# Altium schematic sheet C3 TransmissionBoardSchDoc.SchDoc
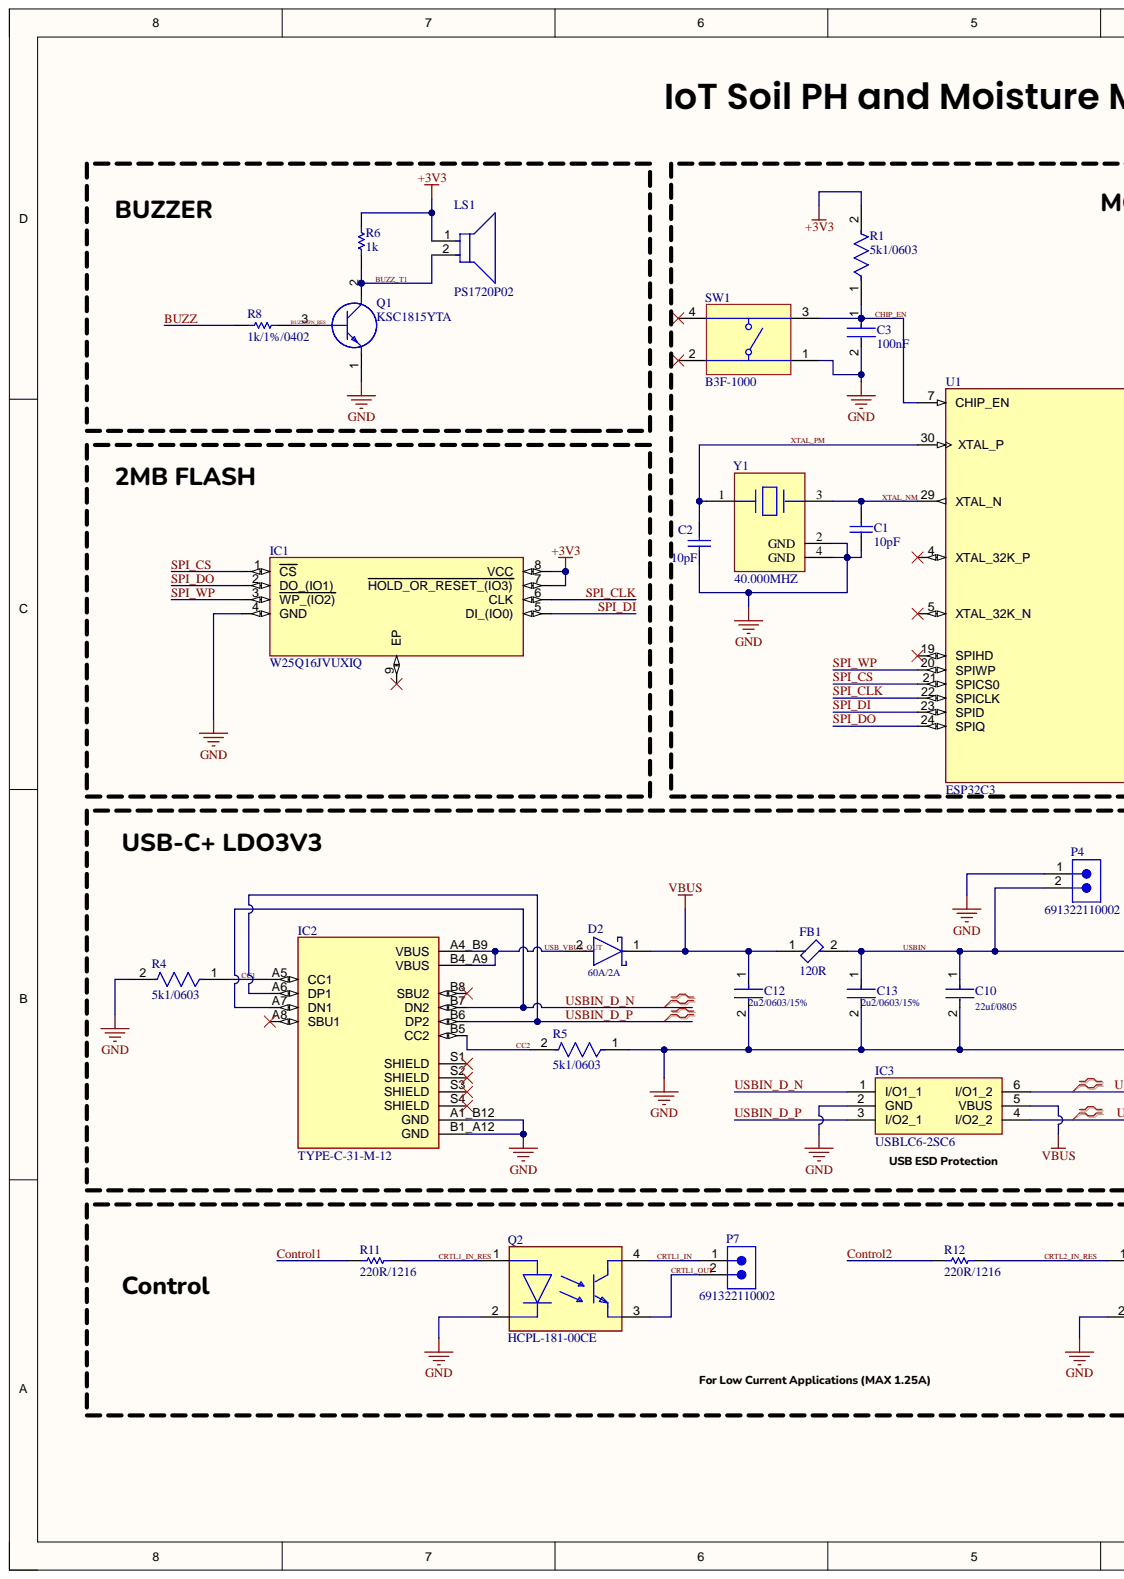
[diagram p0: C3 TransmissionBoardSchDoc.SchDoc, left]
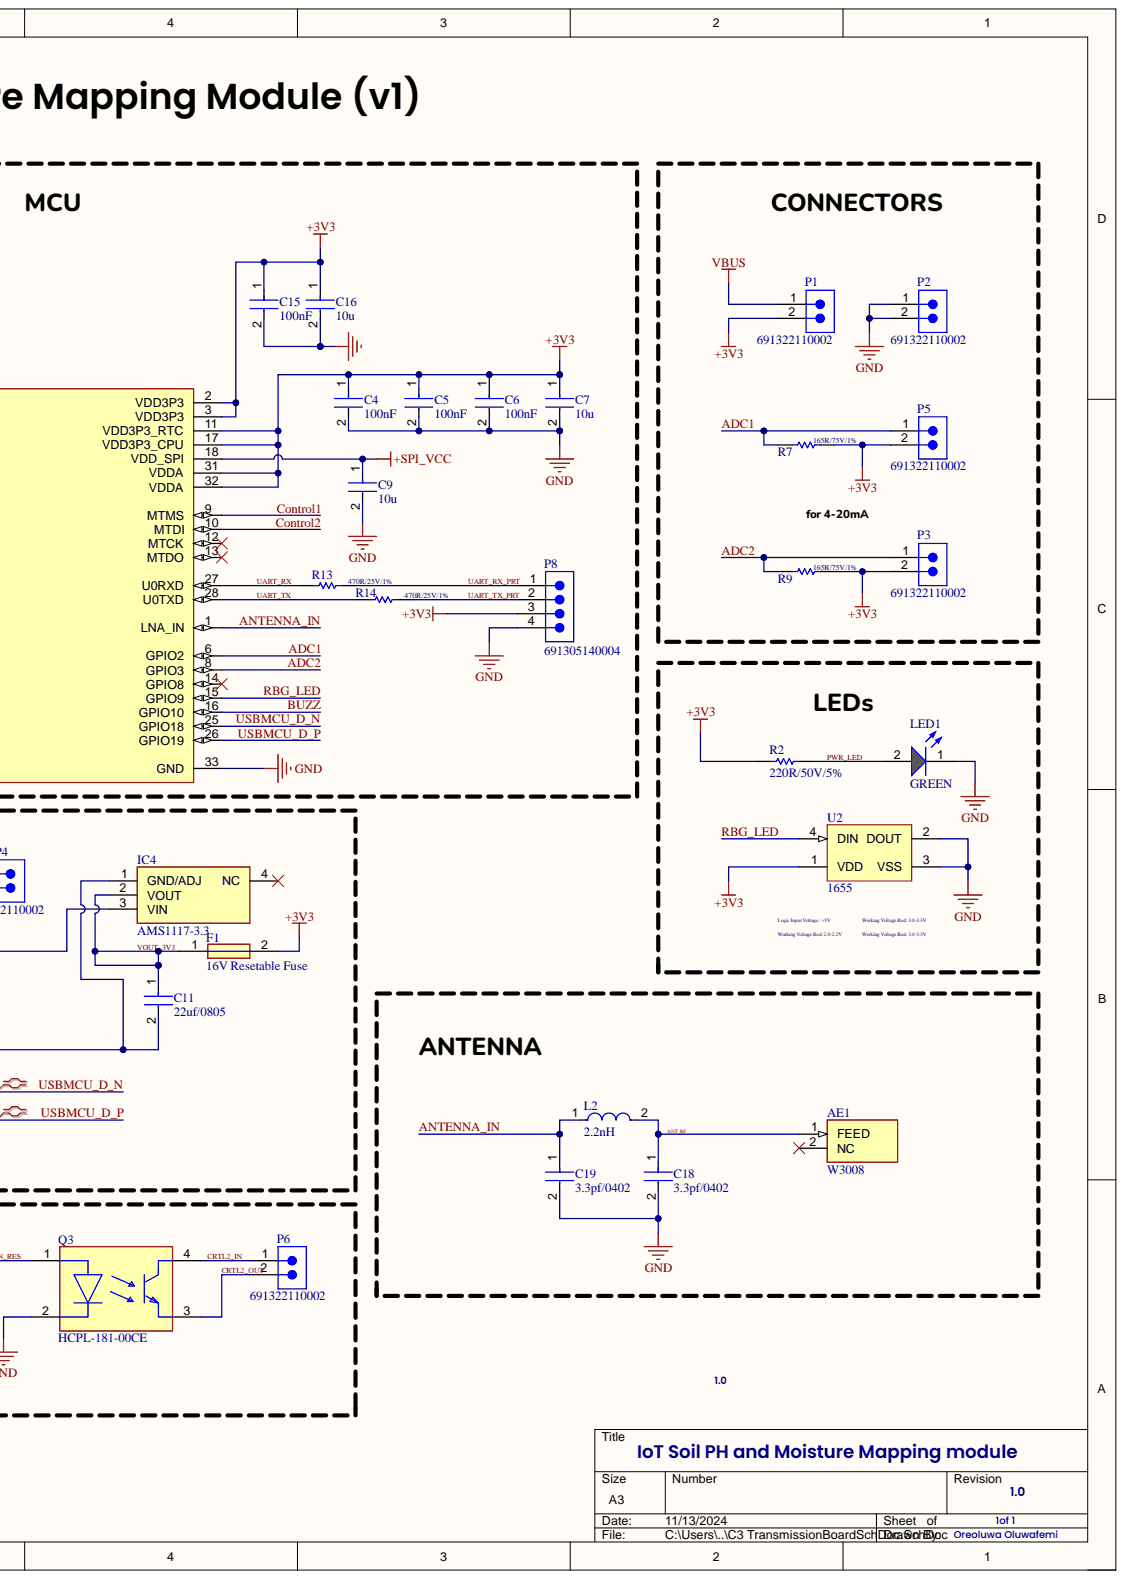
[diagram p1: C3 TransmissionBoardSchDoc.SchDoc, right]

## Parts
AE1  W3008  [ANTC3216X115N]
C1  10pF  [CAPC1608X90X35LL10T15]
C2  10pF  [CAPC1608X90X35LL10T15]
C3  100nF  [FP-GRM033-0_03-MFG]
C4  100nF  [FP-GRM033-0_03-MFG]
C5  100nF  [FP-GRM033-0_03-MFG]
C6  100nF  [FP-GRM033-0_03-MFG]
C7  10u  [FP-GRM188-0_1-e0_2_0_55-IPC_A]
C9  10u  [FP-GRM188-0_1-e0_2_0_55-IPC_A]
C10  22uf/0805  [FP-C0805C226M9PACTU-MFG]
C11  22uf/0805  [FP-C0805C226M9PACTU-MFG]
C12  2u2/0603/15%  [FP-GRM188-0_1-e0_2_0_5-MFG]
C13  2u2/0603/15%  [FP-GRM188-0_1-e0_2_0_5-MFG]
C15  100nF  [FP-GRM033-0_03-MFG]
C16  10u  [FP-GRM188-0_1-e0_2_0_55-IPC_A]
C18  3.3pf/0402  [FP-GJM155-0_05-IPC_B]
C19  3.3pf/0402  [FP-GJM155-0_05-IPC_B]
D2  60A/2A  [FP-SOD123W-MFG]
F1  16V Resetable Fuse  [FUSC4632X160N]
FB1  120R  [FP-BLM18-0_15-t0_8-IPC_A]
IC1  W25Q16JVUXIQ  [SON50P300X200X60-9N]
IC2  TYPE-C-31-M-12  [HRO_TYPE-C-31-M-12]
IC3  USBLC6-2SC6  [SOT95P280X145-6N]
IC4  AMS1117-3.3  [SOT229P700X180-4N]
L2  2.2nH  [FP-LQW18AN_80-MFG]
LED1  GREEN  [WL-SMCW_0603_150060xx75000]
LS1  PS1720P02  [FP-PS1720P02-MFG]
P1  691322110002  [691322110002]
P2  691322110002  [691322110002]
P3  691322110002  [691322110002]
P4  691322110002  [691322110002]
P5  691322110002  [691322110002]
P6  691322110002  [691322110002]
P7  691322110002  [691322110002]
P8  691305140004  [691305140004]
Q1  KSC1815YTA  [BC33740TA]
Q2  HCPL-181-00CE  [FP-SO-4-MFG]
Q3  HCPL-181-00CE  [FP-SO-4-MFG]
R1  5k1/0603  [FP-RT0603-MFG]
R2  220R/50V/5%  [RESC1005X40X25NL05T10]
R4  5k1/0603  [FP-RT0603-MFG]
R5  5k1/0603  [FP-RT0603-MFG]
R6  1k  [RESC1005X40X25NL05T05]
R7  165R/75V/1%  [RESC1608X55X30NL15T15]
R8  1k/1%/0402  [RESC1005X40X25NL05T05]
R9  165R/75V/1%  [RESC1608X55X30NL15T15]
R11  220R/1216  [RESC3216X60X45ML10T20]
R12  220R/1216  [RESC3216X60X45ML10T20]
R13  470R/25V/1%  [RESC0603X26X15LL03T05]
R14  470R/25V/1%  [RESC0603X26X15LL03T05]
SW1  B3F-1000  [OMRON-B3F-1000-4_V]
U1  ESP32C3  [QFN32_5X5MM]
U2  1655  [ADA-RGB-1655_V]
Y1  40.000MHZ  Load Capacitance: 10pF  [FP-ABM8-MFG]

## Nets
+3V3: C4.1 C5.1 C6.1 C7.1 C15.1 C16.1 F1.2 IC1.7(HOLD_OR_RESET_(IO3)) IC1.8(VCC) LS1.1 P1.2 P3.2 P5.2 P8.3 R1.2 R2.1 R6.2 R7.2 R9.2 U1.2(VDD3P3) U1.3(VDD3P3) U1.11(VDD3P3_RTC) U1.17(VDD3P3_CPU) U1.31(VDDA) U1.32(VDDA) U2.1(VDD)
+SPI_VCC: C9.1 U1.18(VDD_SPI)
GND: C1.1 C2.1 C3.2 C4.2 C5.2 C6.2 C7.2 C9.2 C10.2 C11.2 C12.2 C13.2 C15.2 C16.2 C18.2 C19.2 IC1.4(GND) IC2.A1_B12(GND) IC2.B1_A12(GND) IC3.2(GND) IC4.1(GND/ADJ) LED1.1 P2.1 P2.2 P4.1 P8.4 Q1.1 Q2.2 Q3.2 R4.2 R5.1 SW1.1 U1.33(GND) U2.2(DOUT) U2.3(VSS) Y1.2(GND) Y1.4(GND)
VBUS: C12.1 D2.1 FB1.1 IC3.5(VBUS) P1.1
ADC1: P5.1 R7.1 U1.6(GPIO2)
ADC2: P3.1 R9.1 U1.8(GPIO3)
ANT_RF: AE1.1 C18.1 L2.2
ANTENNA_IN: C19.1 L2.1 U1.1(LNA_IN)
BUZZ: R8.2 U1.16(GPIO10)
BUZZ_T1: LS1.2 Q1.2 R6.1
BUZZNPN_RES: Q1.3 R8.1
CC1: IC2.A5(CC1) R4.1
CC2: IC2.B5(CC2) R5.2
CHIP_EN: C3.1 R1.1 SW1.3 U1.7(CHIP_EN)
Control1: R11.1 U1.9(MTMS)
Control2: R12.1 U1.10(MTDI)
CRTL1_IN: P7.1 Q2.4
CRTL1_IN_RES: Q2.1 R11.2
CRTL1_OUT: P7.2 Q2.3
CRTL2_IN: P6.1 Q3.4
CRTL2_IN_RES: Q3.1 R12.2
CRTL2_OUT: P6.2 Q3.3
PWR_LED: LED1.2 R2.2
RBG_LED: U1.15(GPIO9) U2.4(DIN)
SPI_CLK: IC1.6(CLK) U1.22(SPICLK)
SPI_CS: IC1.1(CS) U1.21(SPICS0)
SPI_DI: IC1.5(DI_(IO0)) U1.23(SPID)
SPI_DO: IC1.2(DO_(IO1)) U1.24(SPIQ)
SPI_WP: IC1.3(WP_(IO2)) U1.20(SPIWP)
UART_RX: R13.1 U1.27(U0RXD)
UART_RX_PRT: P8.1 R13.2
UART_TX: R14.1 U1.28(U0TXD)
UART_TX_PRT: P8.2 R14.2
USB_VBUS_OUT: D2.2 IC2.A4_B9(VBUS) IC2.B4_A9(VBUS)
USBIN: C10.1 C13.1 FB1.2 IC4.3(VIN) P4.2
USBIN_D_N: IC2.A7(DN1) IC2.B7(DN2) IC3.1(I/O1_1)
USBIN_D_P: IC2.A6(DP1) IC2.B6(DP2) IC3.3(I/O2_1)
USBMCU_D_N: IC3.6(I/O1_2) U1.25(GPIO18)
USBMCU_D_P: IC3.4(I/O2_2) U1.26(GPIO19)
VOUT_3V3: C11.1 F1.1 IC4.2(VOUT)
XTAL_NM: C1.2 U1.29(XTAL_N) Y1.3
XTAL_PM: C2.2 U1.30(XTAL_P) Y1.1

Not connected: AE1 2; IC1 9(EP); IC2 A8(SBU1) B8(SBU2) S1(SHIELD) S2(SHIELD) S3(SHIELD) S4(SHIELD); IC4 4(NC); SW1 2 4; U1 4(XTAL_32K_P) 5(XTAL_32K_N) 12(MTCK) 13(MTDO) 14(GPIO8) 19(SPIHD)

## Library data of the parts
AE1 = W3008: Antenna; Manufacturer_Name: PULS; Component Kind: Standard; Datasheet Link: https://source.z2data.com/2019/5/5/2/28/32/733/W3008.pdf; Height: 1.15mm; Manufacturer_Part_Number: W3008
C1 C2 = CMP-2006-02592-1: Manufacturer: Murata; Part Number: GRM1885C1H100JA01D; Component Kind: Standard; Library Name: Altium Content Vault
C3-C6 C15 = CMP-06035-000062-2: Chip Multilayer Ceramic Capacitors for General Purpose, 0201, 0.10uF, X5R, 15%, 10%, 6.3V; Capacitance: 100nF; Case Code (Imperial): 0201; Case Code (Metric): 0603; Case/Package: 0201; Component Kind: Standard; Depth: 0.3mm; Dielectric: X5R; Height: 0.3mm; Lead Free: Lead Free; Length: 0.6mm; Library Name: Altium Content Vault; Material: Ceramic; Max Operating Temperature: 85°C; Min Operating Temperature: -55°C; Mount: Surface Mount; Package Quantity: 1; Packaging: Tape and Reel; Radiation Hardening: No; REACH SVHC: No SVHC; Termination: SMD/SMT; Thickness: 0.013inch; Tolerance: 10%; Voltage: 6.3V; Voltage Rating: 6.3V; Voltage Rating (DC): 6.3V; Weight: 6E-06oz; Width: 0.012inch
C7 C9 C16 = CMP-2006-02792-2: Chip Multilayer Ceramic Capacitors for General Purpose, 0603, 10uF, X5R, 15%, 20%, 6.3V; Capacitance: 10uF; Case Code (Imperial): 0603; Case Code (Metric): 1608; Case/Package: 0603; Component Kind: Standard; Depth: 0.8mm; Dielectric: X5R; Height: 0.8mm; Lead Free: Lead Free; Length: 1.6mm; Library Name: Altium Content Vault; Material: Ceramic; Max Operating Temperature: 85°C; Min Operating Temperature: -55°C; Mount: Surface Mount; Package Quantity: 4000; Packaging: Tape and Reel; Radiation Hardening: No; REACH SVHC: No SVHC; Termination: SMD/SMT; Thickness: 0.035inch; Tolerance: 20%; Voltage: 6.3V; Voltage Rating: 6.3V; Voltage Rating (DC): 6.3V; Width: 0.031inch
C10 C11 = CMP-2007-01021-2: CAP CER 22UF 6.3V X5R 0805; Dielectric Material: Ceramic; Dissipation Factor: 5%; Tolerance: 20%; Capacitance: 22uF; Case Code (Imperial): 0805; Case Code (Metric): 2012; Case/Package: 0805; Component Kind: Standard; Construction: Flat; Depth: 1.25mm; Dielectric: X5R; Height: 1.25mm; Lead Free: Lead Free; Length: 2mm; Library Name: Altium Content Vault; Max Operating Temperature: 85°C; Min Operating Temperature: -55°C; Mount: Surface Mount; Number of Pins: 2; Package Quantity: 2500; Packaging: Tape and Reel; Radiation Hardening: No; REACH SVHC: No SVHC; RoHS Compliant: Yes; Termination: SMD/SMT; Voltage: 6.3V; Voltage Rating: 6.3V; Voltage Rating (DC): 6.3V; Width: 1.2mm
C12 C13 = CMP-2006-02836-2: Chip Multilayer Ceramic Capacitors for General Purpose, 0603, 2.2uF, X7R, 15%, 10%, 10V; Capacitance: 2.2uF; Case Code (Imperial): 0603; Case Code (Metric): 1608; Case/Package: 0603; Component Kind: Standard; Depth: 0.8mm; Dielectric: X7R; Height: 0.8mm; Lead Free: Lead Free; Length: 1.6mm; Library Name: Altium Content Vault; Material: Ceramic; Max Operating Temperature: 125°C; Min Operating Temperature: -55°C; Mount: Surface Mount; Number of Pins: 2; Package Quantity: 1; Packaging: Cut Tape; REACH SVHC: No SVHC; Termination: SMD/SMT; Thickness: 0.035inch; Tolerance: 10%; Voltage: 10V; Voltage Rating: 10V; Voltage Rating (DC): 10V; Weight: 7.1E-05oz; Width: 0.031inch
C18 C19 = CMP-2008-03847-2: Multi-Layer Ceramic Capacitor 3.3pF C0G  ±0.25pF 0402 Paper T/R; Voltage: 50V; Capacitance: 3.3pF; Case Code (Imperial): 0402; Case Code (Metric): 1005; Case/Package: 0402; Component Kind: Standard; Depth: 0.5mm; Dielectric: C0G; Height: 0.5mm; Lead Free: Lead Free; Length: 1mm; Library Name: Altium Content Vault; Max Operating Temperature: 125°C; Min Operating Temperature: -55°C; Mount: Surface Mount; Package Quantity: 1; Packaging: Tape and Reel; Radiation Hardening: No; Termination: SMD/SMT; Thickness: 0.022inch; Voltage Rating: 50V; Voltage Rating (DC): 50V; Width: 0.5mm
D2 = CMP-14398-000040-1: DIODE SCHOTTKY 60V 2A CFP3; Component Kind: Standard; Library Name: Altium Content Vault; RoHS Compliant: Yes
F1 = MF-MSMF250_16X: Fuse; Manufacturer_Name: Bourns; Manufacturer_Part_Number: MF-MSMF250/16X; Component Kind: Standard; Datasheet Link: https://www.bourns.com/docs/Product-Datasheets/mfmsmf.pdf; Height: 1.6mm
FB1 = CMP-0686-00557-7: Chip Ferrite Bead, 0603, 120Ω @ 100MHz, 0.05Ω, 25%, 2A; DC Resistance (DCR): 50mR; Material: Steel; Number of Circuits: 1; Shielding: Unshielded; Case Code (Imperial): 0603; Case Code (Metric): 1608; Case/Package: 0603; Component Kind: Standard; Core Material: Ferrite; Current Rating: 2A; DC Current: 2A; Depth: 0.8mm; Frequency: 100MHz; Height: 0.8mm; Impedance: 120R; Lead Free: Lead Free; Length: 1.6mm; Library Name: Altium Content Vault; Max Current Rating: 2A; Max DC Current: 2A; Max Operating Temperature: 125°C; Min Operating Temperature: -55°C; Number of Lines: 1; Number of Pins: 2; Package Quantity: 1; Packaging: Tape and Reel; Radiation Hardening: No; REACH SVHC: No SVHC; RoHS Compliant: Yes; Termination: SMD/SMT; Test Frequency: 100MHz; Tolerance: 25%; Width: 0.8mm
IC1 = CMP-031-00015-1: NOR Flash Serial (SPI, Dual SPI, Quad SPI) 3V/3.3V 16M-bit 2M x 8 6ns 8-Pin USON EP T/R; MF: Winbond; Availability: In Stock; Case/Package: UFDFN-8 Winbond; Check_prices: https://www.snapeda.com/parts/W25Q16JVUXIQ/Winbond/view-part/?ref=eda; Component Kind: Standard; Component Type: Standard; Design Item ID: W25Q16JVUXIQ; MP: W25Q16JVUXIQ; Pins: 9; Price: None; Purchase-URL: https://pricing.snapeda.com/search/part/W25Q16JVUXIQ/?ref=eda; SnapEDA_Link: https://www.snapeda.com/parts/W25Q16JVUXIQ/Winbond/view-part/?ref=snap; Source: W25Q16JVUXIQ.SchLib; Library Name: Oreoluwa's 3rd Altium
IC2 = CMP-002-00071-1: USB Connectors 24 Receptacle 1 8.94*7.3mm RoHS; MF: HRO Electronics Co., Ltd.; Availability: Not in stock; Case/Package: Package; Check_prices: https://www.snapeda.com/parts/TYPE-C-31-M-12/HRO+Electronics+Co.%252C+Ltd./view-part/?ref=eda; Component Kind: Standard; Component Type: Standard; Design Item ID: TYPE-C-31-M-12; MP: TYPE-C-31-M-12; Pins: 16; Price: None; SnapEDA_Link: https://www.snapeda.com/parts/TYPE-C-31-M-12/HRO+Electronics+Co.%252C+Ltd./view-part/?ref=snap; Source: TYPE-C-31-M-12.SchLib; Library Name: Oreoluwa's 3rd Altium
IC3 = USBLC6-2SC6: Integrated Circuit; Manufacturer_Name: STMicroelectronics; Arrow Part Number: USBLC6-2SC6; Arrow Price/Stock: https://www.arrow.com/en/products/usblc6-2sc6/stmicroelectronics?region=nac; Component Kind: Standard; Datasheet Link: http://www.st.com/st-web-ui/static/active/en/resource/technical/document/datasheet/CD00050750.pdf; Height: 1.45mm; Manufacturer_Part_Number: USBLC6-2SC6; Mouser Part Number: 511-USBLC6-2SC6; Mouser Price/Stock: https://www.mouser.co.uk/ProductDetail/STMicroelectronics/USBLC6-2SC6?qs=po45yt2pPpu%2FhNIlwQdTlg%3D%3D; Supplier 1: Mouser; Supplier Part Number 1: 511-USBLC6-2SC6
IC4 = AMS1117-3.3: Integrated Circuit; Manufacturer_Name: Advanced; Component Kind: Standard; Datasheet Link: https://datasheet.datasheetarchive.com/originals/distributors/Datasheets-28/DSA-550594.pdf; Height: 1.8mm; Manufacturer_Part_Number: AMS1117-3.3
L2 = CMP-06042-009804-1: Wire Wound RF Inductor 2.2nH ±0.2nH 3200mA 0.018Ω 0603 (1608); Current Rating: 3.2A; DC Resistance (DCR): 18mR; Self Resonant Frequency: 15GHz; Shielding: Unshielded; Test Frequency: 100MHz; Case/Package: 0603; Component Kind: Standard; Height - Seated (Max): 0.039inch; Inductance: 2.2nH; Length: 0.065inch; Library Name: Altium Content Vault; Max Operating Temperature: 125°C; Min Operating Temperature: -55°C; Mount: Surface Mount; Package Quantity: 4000; Packaging: Tape and Reel; Resistor Type: Wirewound; Width: 0.035inch
LED1 = CMP-1426-00006-6: NexarPartId: 29717778; Dominant Wavelength: 570nm; Forward Current: 100mA; Forward Voltage: 2V; Lens Style: Clear, Rectangular; Luminous Intensity: 40mcd; Max Junction Temperature (Tj): 95°C; Max Reverse Voltage (DC): 5V; Peak Wavelength: 572nm; Power Dissipation: 72mW; Test Current: 20mA; Viewing Angle: 140°; Case Code (Imperial): 0603; Case Code (Metric): 1608; Case/Package: 0603; Color: Green; Component Kind: Standard; Height: 700µm; Length: 1.6mm; Library Name: Altium Content Vault; Max Operating Temperature: 85°C; Min Operating Temperature: -40°C; Mount: Surface Mount; Number of Pins: 2; Packaging: Tape and Reel; REACH SVHC: Unknown; Reverse Voltage: 5V; RoHS: Compliant; Wavelength: 570nm; Width: 800µm
LS1 = CMP-08413-000001-1: AUDIO PIEZO TRANSDUCER 30V TH; Manufacturer: TDK Corporation; Frequency: 2kHz; Standoff Height: 0.5mm; Automotive: No; Category: Speak; Component Kind: Standard; ComponentLink2Description: Datasheet; ComponentLink2URL: https://product.tdk.com/info/en/catalog/datasheets/piezoelectronic_buzzer_ps_en.pdf; Device Class L1: Electromechanical; Device Class L2: Audio Products; Device Class L3: Buzzers; Digikey Description: AUDIO PIEZO TRANSDUCER 30V TH; Height: 8.3mm; Lead Free: Yes; Library Name: Altium Content Vault; Manufacturer Part Number: PS1720P02; Mouser Description: Audio Indicators & Alerts Round 17mmx8mm 2 kHz Vin=3V; Octopart: https://octopart.com/ps1720p02-tdk-154429; Package: 0; RoHS: TRUE; Temperature: -10°C to +70°C; Temperature Range High: +70°C; Temperature Range Low: -10°C
P1-P7 = CMP-1502-01791-3: NexarPartId: 18818346; Current: 10A; Gender: Male; Number of Contacts: 2; Number of Levels: 1; Pitch: 3.5052mm; Voltage: 300V; Color: Green; Component Kind: Standard; Contact Material: Brass; Current Rating: 10A; Height: 7.4mm; Lead Free: Lead Free; Lead Pitch: 3.5mm; Length: 10.5mm; Library Name: Altium Content Vault; Max Operating Temperature: 105°C; Min Operating Temperature: -40°C; Mount: Through Hole; Number of Pins: 2; Number of Positions Per Level: 2; Number of Rows: 1; Packaging: Box; REACH SVHC: Unknown; RoHS: Compliant; Termination: Solder; Voltage Rating: 300V; Width: 8.1mm
P8 = CMP-1502-01777-2: NexarPartId: 78871379; Gender: Male; Component Kind: Standard; Lead Pitch: 3.5mm; Library Name: Altium Content Vault; Number of Pins: 4; Packaging: Box; RoHS: Non-Compliant
Q1 = CMP-030-000000-1: Transistor; Manufacturer_Name: onsemi; Arrow Part Number: KSC1815YTA; Arrow Price/Stock: https://www.arrow.com/en/products/ksc1815yta/on-semiconductor?region=nac; Component Kind: Standard; Datasheet Link: https://www.onsemi.com/products/discretes-drivers/general-purpose-and-low-vcesat-transistors/ksc1815; Design Item ID: KSC1815YTA; Manufacturer_Part_Number: KSC1815YTA; Mouser Part Number: 512-KSC1815YTA; Mouser Price/Stock: https://www.mouser.co.uk/ProductDetail/onsemi-Fairchild/KSC1815YTA?qs=ljbEvF4DwOP9FJQWOCoFLQ%3D%3D; Source: SamacSys.SchLib; Library Name: Oreoluwa's 3rd Altium
Q2 Q3 = CMP-18400-000021-1: OPTOISO 3.75KV TRANS 4MINIFLAT; Manufacturer: Broadcom; Collector Emitter Voltage: 80V; Emiiter Collector Voltage: 6V; Forward Current: 20mA; Forward Voltage: 1.2V; Isolation Voltage: 3750Vrms; Max Current Transfer Ratio: 400%; Min Current Transfer Ratio: 200%; Power Dissipation: 170mW; Standoff Height: 0mm; Turn Off Delay Time: 4us; Turn On Delay Time: 3us; Ambient Temperature Range High: +100°C; Ambient Temperature Range Low: -55°C; Automotive: No; Category: Trans; Component Kind: Standard; ComponentLink2Description: Datasheet; ComponentLink2URL: https://docs.broadcom.com/docs/AV02-0774EN; Device Class L1: Optoelectronics; Device Class L2: Optocouplers; Device Class L3: unset; Digikey Description: OPTOISO 3.75KV TRANS 4MINIFLAT; Height: 2.4mm; Ipc Land Pattern Name: SOIC254P700X210-4; Lead Free: Yes; Library Name: Altium Content Vault; Manufacturer Part Number: HCPL-181-00CE; Mouser Description: Transistor Output Optocouplers 3750 Vrms 0.2mA; Octopart: https://octopart.com/hcpl-181-00ce-broadcom-71128032; Package: SO-4; Reverse Voltage: 6V; RoHS: TRUE; Temperature: -55°C to +100°C; Temperature Range High: +100°C; Temperature Range Low: -55°C
R1 R4 R5 = CMP-2000-04778-2: RES SMD 5.1K OHM 1% 1/10W 0603; Manufacturer: Yageo; Manufacturer Part Number: RT0603FRE075K1L; Power Rating: 0.1W; Resistance: 5.1KΩ; Temperature Coefficient: 50 ppm/°C; Tolerance: 0.01; Value: 5.1KΩ; Voltage Rating: 75V; Automotive: No; Category: Res; Component Kind: Standard; ComponentLink2Description: Datasheet; ComponentLink2URL: http://www.yageo.com/documents/recent/PYu-RT_1-to-0.01_RoHS_L_9.pdf; Composition: Thin Film; Device Class L1: Passive Components; Device Class L2: Resistors; Device Class L3: Chip SMD Resistors; Digikey Description: RES SMD 5.1K OHM 1% 1/10W 0603; Footprint Url: http://www.yageo.com/exep/pages/download/literatures/PYu-R_Mount_10.pdf; Height: 0.55mm; Ipc Land Pattern Name: RESC16080X45; Lead Free: Yes; Library Name: Altium Content Vault; Mouser Description: Res Thin Film 0603 5.1K Ohm 1% 0.1W(1/10W) ±50ppm/C Epoxy Pad SMD T/R; Octopart: https://octopart.com/rt0603fre075k1l-yageo-1421390; Package: RT0603; RoHS: TRUE; Series: RT; Temperature: -55°C to +155°C; Temperature Range High: +155°C; Temperature Range Low: -55°C
R2 = CMP-2002-07959-1: Manufacturer: Yageo / Phycomp; Part Number: RC0402JR-07220RL; Component Kind: Standard; Library Name: Altium Content Vault
R6 R8 = CMP-1011-00510-4: Chip Resistor, 1 KOhm, +/- 1%, 100 mW, -55 to 155 degC, 0402 (1005 Metric), RoHS, Tape and Reel; Manufacturer: Basic Passives; PartNumber: ERJ-2RKF1001X; Case\/Package: 0402; Power Rating: 100 mW; Resistance: 1 KOhm; Voltage Rating: 50 V; Case Code (Imperial): 0402; Case Code (Metric): 1005; Component Kind: Standard; ComponentLink1Description: Manufacturer URL; ComponentLink1URL: http://www.panasonic.com/; ComponentLink2Description: Datasheet; ComponentLink2URL: http://industrial.panasonic.com/cdbs/www-data/pdf/RDA0000/AOA0000C86.pdf; DatasheetVersion: 04/2015; Height: 0.35 mm; Length: 1 mm; Library Name: Altium Content Vault; Max Operating Temperature: 155 degC; Min Operating Temperature: -55 degC; Mount: Surface Mount; Mounting Technology: Surface Mount; Package Quantity: 10000; PackageDescription: 2-Pin Surface Mount Device, Body 1 x 0.5 mm; PackageReference: 0402; Packaging: Tape and Reel; Pins: 2; Radiation Hardening: No; REACH SVHC: Yes; RoHSCompliant: true; Tolerance: 1%; Width: 0.5 mm
R7 R9 = CMP-2000-00277-1: Manufacturer: Panasonic; Part Number: ERJ-3EKF1650V; Component Kind: Standard; Library Name: Altium Content Vault
R11 R12 = CMP-2003-01956-1: Manufacturer: Vishay Dale; Part Number: CRCW1206220RFKEA; Component Kind: Standard; Library Name: Altium Content Vault
R13 R14 = CMP-1010-00379-2: Manufacturer: Panasonic; Part Number: ERJ1GNF4700C; Component Kind: Standard; Library Name: Altium Content Vault
SW1 = CMP-1677-00002-1: Tactile Switch, SPST-NO, 0.05 A, 5 to 24 V, -25 to 70 degC, 4-Pin THD, RoHS, Bulk; Capacitance: -45 f; Current Rating: 1.5 A; Gender: Female; Shielding: Shielded; Voltage Rating: 150 V; Component Kind: Standard; ComponentLink1Description: Manufacturer URL; ComponentLink1URL: http://www.omron.com/; ComponentLink2Description: Datasheet; ComponentLink2URL: http://www.omron.com/ecb/products/pdf/en-b3f.pdf; Contact Material: Brass; Contact Plating: Gold; DatasheetVersion: Jan-15; Height: 14.99 mm; Lead Pitch: 1.27 mm; Length: 18.34 mm; Library Name: Altium Content Vault; Max Operating Temperature: 70 degC; Min Operating Temperature: -40 degC; Mount: Through Hole; Package Quantity: 1080; PackageDescription: 4-Pin Through Hole Device, Body 6 x 6 mm; PackageReference: B3F-1000; Packaging: Tray; Pins: 8; Radiation Hardening: No; RoHSCompliant: true; Width: 25.4 mm
U1 = CMP-031-00006-1: WiFi Modules (802.11) (Engineering Samples Only) SMD IC ESP32-C3, RISC-V single-core MCU, 2.4G Wi-Fi & BLE 5.0 combo, QFN 32-pin, 5*5 mm, 40 ~ 105C; MF: Espressif Systems; Availability: In Stock; Case/Package: VFQFN-32 Espressif Systems; Check_prices: https://www.snapeda.com/parts/ESP32-C3/Espressif+Systems/view-part/?ref=eda; Component Kind: Standard; ComponentLink1Description: New link; Design Item ID: ESP32C3; MP: ESP32-C3; Price: None; Purchase-URL: https://pricing.snapeda.com/search?q=ESP32-C3&ref=eda; SnapEDA_Link: https://www.snapeda.com/parts/ESP32-C3/Espressif+Systems/view-part/?ref=snap; Source: ESP32C3.SchLib; Library Name: Oreoluwa's 3rd Altium
U2 = CMP-2000-05026-1: Intelligent Control LED Integrated Light Source, 3.5 to 5.3 V, -25 to 80 degC, 4-Pin SMD, RoHS, Tape and Reel; Manufacturer: Adafruit-Industries-LLC; Configuration: Discrete; Number of LEDs: 10; Utilized LED: WS2812(5050); Category: Optoelectronics; Color: Red,Green,Blue(RGB); Component Kind: Standard; Controller Type: -; Datasheet URL: http://datasheet.octopart.com/ADA1655-Adafruit-Industries-datasheet-32028139.pdf; Datasheet Version: May-13; Family: Addressable,Specialty; Interface: -; Library Name: Altium Content Vault; Manufacturer URL: https://www.adafruit.com/; Mounting Type: SurfaceMount; Package Description: 4-Pin Surface Mount Device, Body 5 x 5 mm; Package Reference: 1655; Series: Neopixel; Size / Dimension: 5.00mmLx5.00mmW
Y1 = CMP-27762-008947-1: Crystal 40MHz ±10ppm 10pF SMD-4 3.2mm x 2.5mm; ESR (Equivalent Series Resistance): 35R; Case/Package: SMD/SMT; Component Kind: Standard; Frequency: 40MHz; Frequency Stability: 10ppm; Frequency Tolerance: 10ppm; Height: 0.031inch; Lead Free: Lead Free; Length: 0.126inch; Library Name: Altium Content Vault; Max Operating Temperature: 60°C; Min Operating Temperature: -10°C; Mount: Surface Mount; Number of Pins: 4; Operating Frequency: 40 MHz; Package Quantity: 1000; Packaging: Tape and Reel; Radiation Hardening: No; Resistor Type: Ceramic; Series: ABM8; Termination: SMD/SMT; Tolerance: 10ppm; Width: 0.098inch
